# Altium schematic sheet HMIv2.SchDoc
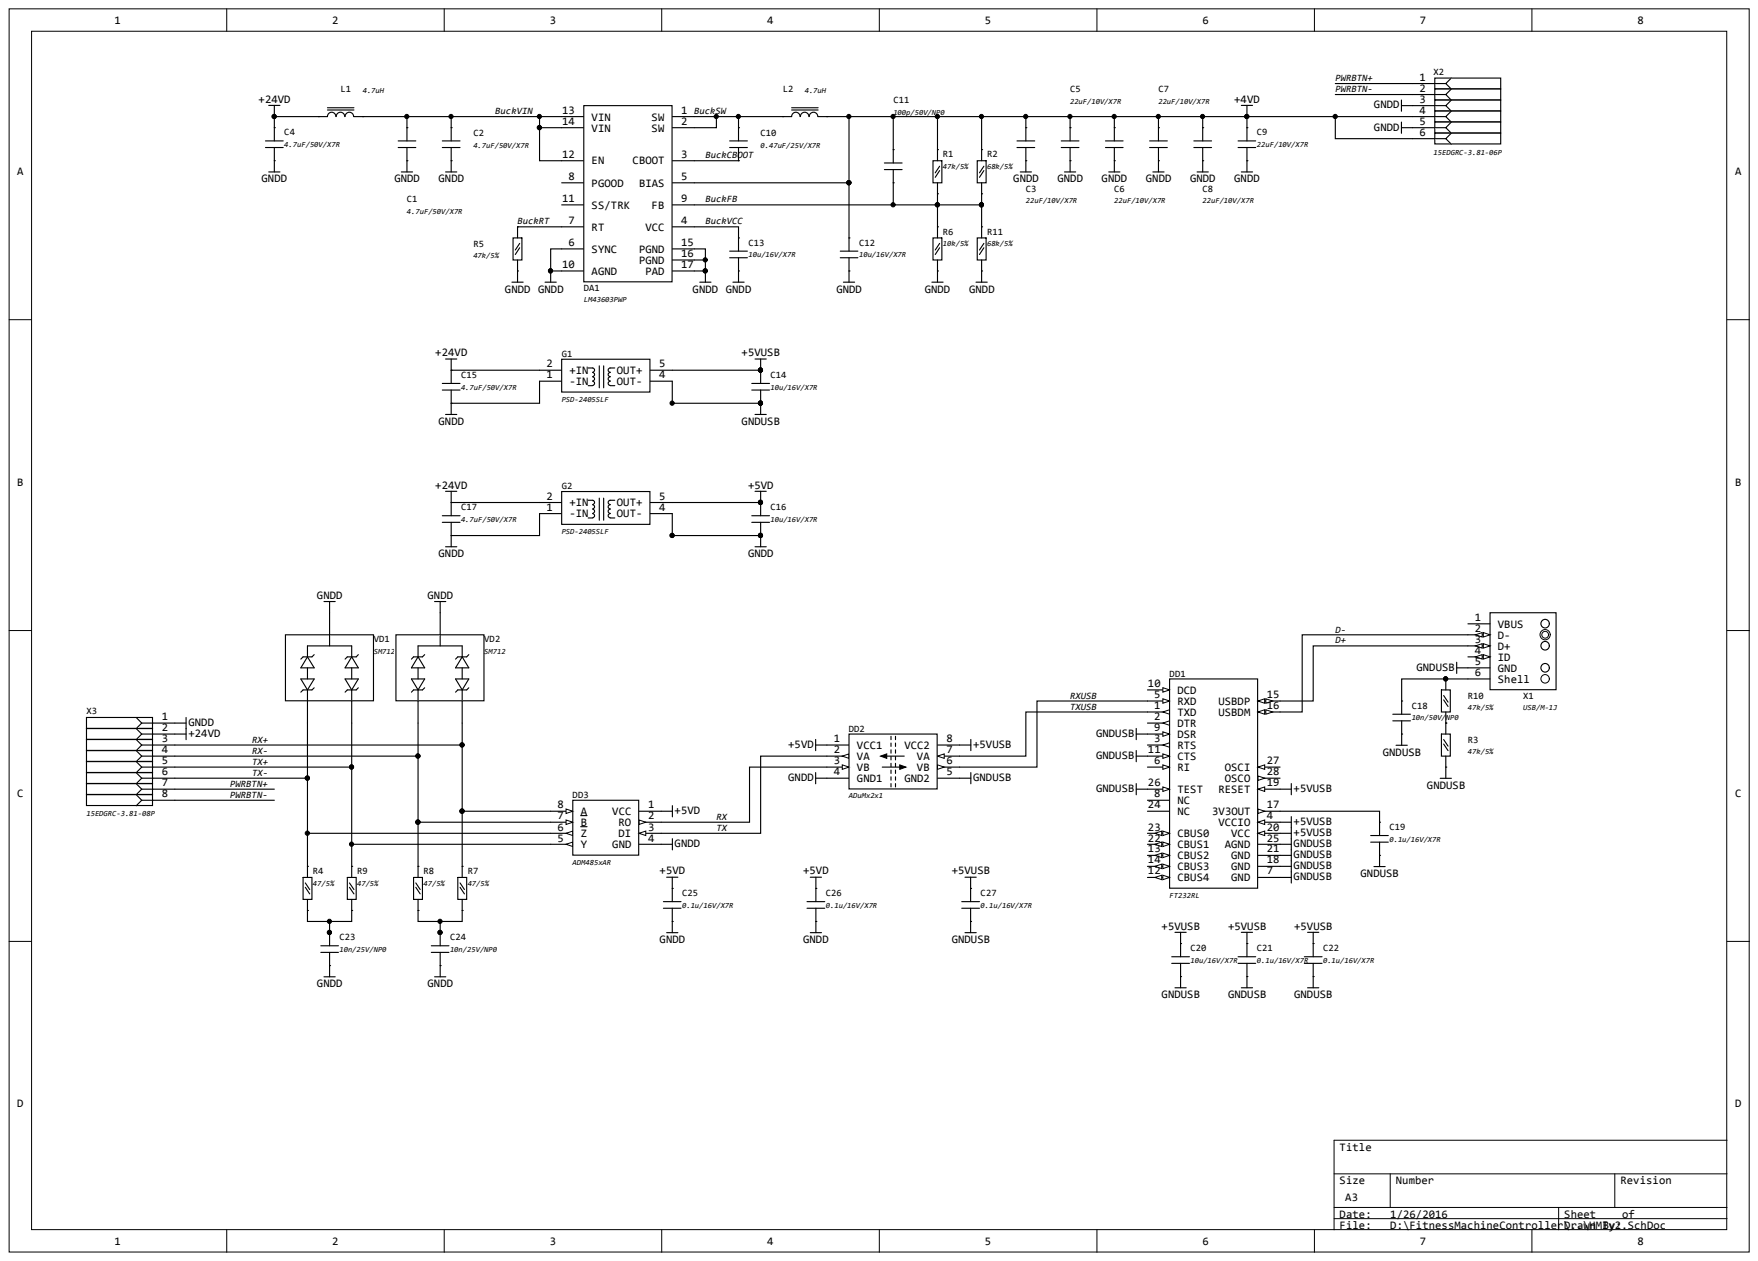
[diagram p0: HMIv2.SchDoc]

## Parts
C1  4.7uF/50V/X7R
C2  4.7uF/50V/X7R
C3  22uF/10V/X7R
C4  4.7uF/50V/X7R
C5  22uF/10V/X7R
C6  22uF/10V/X7R
C7  22uF/10V/X7R
C8  22uF/10V/X7R
C9  22uF/10V/X7R
C10  0.47uF/25V/X7R
C11  100p/50V/NP0
C12  10u/16V/X7R
C13  10u/16V/X7R
C14  10u/16V/X7R
C15  4.7uF/50V/X7R
C16  10u/16V/X7R
C17  4.7uF/50V/X7R
C18  10n/50V/NP0
C19  0.1u/16V/X7R
C20  10u/16V/X7R
C21  0.1u/16V/X7R
C22  0.1u/16V/X7R
C23  10n/25V/NP0
C24  10n/25V/NP0
C25  0.1u/16V/X7R
C26  0.1u/16V/X7R
C27  0.1u/16V/X7R
DA1  LM43603PWP
DD1  FT232RL
DD2  ADuMx2x1
DD3  ADM485xAR
G1  PSD-2405SLF
G2  PSD-2405SLF
L1  4.7uH
L2  4.7uH
R1  47k/5%
R2  68k/5%
R3  47k/5%
R4  47/5%
R5  47k/5%
R6  10k/5%
R7  47/5%
R8  47/5%
R9  47/5%
R10  47k/5%
R11  68k/5%
VD1  SM712
VD2  SM712
X1  USB/M-1J
X2  15EDGRC-3.81-06P
X3  15EDGRC-3.81-08P

## Nets
+4VD: C3.2 C5.2 C6.2 C7.2 C8.2 C9.2 C11.2 C12.2 DA1.5(BIAS) L2.2 R1.2 R2.2 X2.4 X2.6
+5VD: C16.2 C25.2 C26.2 DD2.1(VCC1) DD3.1(VCC) G2.5(OUT+)
+5VUSB: C14.2 C20.2 C21.2 C22.2 C27.2 DD1.4(VCCIO) DD1.19(RESET) DD1.20(VCC) DD2.8(VCC2) G1.5(OUT+)
+24VD: C4.2 C15.2 C17.2 G1.2(+IN) G2.2(+IN) L1.1 X3.2
GNDD: C1.1 C2.1 C3.1 C4.1 C5.1 C6.1 C7.1 C8.1 C9.1 C12.1 C13.1 C15.1 C16.1 C17.1 C23.1 C24.1 C25.1 C26.1 DA1.6(SYNC) DA1.10(AGND) DA1.15(PGND) DA1.16(PGND) DA1.17(PAD) DD2.4(GND1) DD3.4(GND) G1.1(-IN) G2.1(-IN) G2.4(OUT-) R5.1 R6.1 R11.1 VD1.3 VD2.3 X2.3 X2.5 X3.1
GNDUSB: C14.1 C18.2 C19.1 C20.1 C21.1 C22.1 C27.1 DD1.7(GND) DD1.9(DSR) DD1.11(CTS) DD1.18(GND) DD1.21(GND) DD1.25(AGND) DD1.26(TEST) DD2.5(GND2) G1.4(OUT-) R3.1 X1.5(GND)
BUCKCBOOT: C10.1 DA1.3(CBOOT)
BUCKFB: C11.1 DA1.9(FB) R1.1 R2.1 R6.2 R11.2
BUCKRT: DA1.7(RT) R5.2
BUCKSW: C10.2 DA1.1(SW) DA1.2(SW) L2.1
BUCKVCC: C13.2 DA1.4(VCC)
BUCKVIN: C1.2 C2.2 DA1.12(EN) DA1.13(VIN) DA1.14(VIN) L1.2
D+: DD1.15(USBDP) X1.3(D+)
D-: DD1.16(USBDM) X1.2(D-)
PWRBTN+: X2.1 X3.7
PWRBTN-: X2.2 X3.8
RX: DD2.3(VB) DD3.2(RO)
RX+: DD3.8(A) R7.2 VD2.1 X3.3
RX-: DD3.7(B) R8.2 VD2.2 X3.4
RXUSB: DD1.5(RXD) DD2.6(VB)
TX: DD2.2(VA) DD3.3(DI)
TX+: DD3.5(Y) R9.2 VD1.1 X3.5
TX-: DD3.6(Z) R4.2 VD1.2 X3.6
TXUSB: DD1.1(TXD) DD2.7(VA)
NetC18_1: C18.1 R10.2 X1.6(Shell)
NetC19_2: C19.2 DD1.17(3V3OUT)
NetC23_2: C23.2 R4.1 R9.1
NetC24_2: C24.2 R7.1 R8.1
NetR3_2: R3.2 R10.1

Not connected: DA1 8(PGOOD) 11(SS/TRK); DD1 2(DTR) 3(RTS) 6(RI) 8(NC) 10(DCD) 12(CBUS4) 13(CBUS2) 14(CBUS3) 22(CBUS1) 23(CBUS0) 24(NC) 27(OSCI) 28(OSCO); X1 1(VBUS) 4(ID)

## Library data of the parts
C1-C9 C15 C17 = (no symbol name): ComponentDescriptionRUS: Конденсатор SMD 1210; Analog: нет; NumberSolderPointsSMD: 0; NumberSolderPointsTHT: 0
C10 C18 = (no symbol name): ComponentDescriptionRUS: Конденсатор SMD 0805; Analog: нет; NumberSolderPointsSMD: 0; NumberSolderPointsTHT: 0
C11 C23 C24 = (no symbol name): Описание: Конденсатор SMD 0603; NumberSolderPointsSMD: 0; Аналог: нет; NumberSolderPointsTHT: 0
C12-C14 C16 C20 = (no symbol name): ComponentDescriptionRUS: Конденсатор SMD 1206; Analog: нет; NumberSolderPointsSMD: 0; NumberSolderPointsTHT: 0
C19 C21 C22 C25-C27 = (no symbol name): ComponentDescriptionRUS: Конденсатор SMD 0603; Analog: нет; NumberSolderPointsSMD: 0; NumberSolderPointsTHT: 0
DA1 = (no symbol name): ComponentDescriptionRUS: Микросхема TSSOP16
DD1 = (no symbol name): ComponentDescriptionRUS: Микросхема SSOP28; Analog: нет; NumberSolderPointsSMD: 0; NumberSolderPointsTHT: 0
DD2 = (no symbol name): ComponentDescriptionRUS: Микросхема SO8; Analog: нет; NumberSolderPointsSMD: 0; NumberSolderPointsTHT: 0
DD3 = (no symbol name): ComponentDescriptionRUS: Микросхема SO8; Аналог: нет; NumberSolderPointsSMD: 0; NumberSolderPointsTHT: 0
G1 G2 = (no symbol name): ComponentDescriptionRUS: Модуль питания; Analog: нет; NumberSolderPointsSMD: 0; NumberSolderPointsTHT: 0
L1 L2 = (no symbol name): ComponentDescriptionRUS: Индуктивность; Analog: нет; NumberSolderPointsSMD: 0; NumberSolderPointsTHT: 0
R1-R4 R6-R11 = (no symbol name): ComponentDescriptionRUS: Резистор SMD 0603; Analog: нет; NumberSolderPointsSMD: 0; NumberSolderPointsTHT: 0
R5 = (no symbol name): Описание: Резистор SMD 0805; NumberSolderPointsSMD: 0; Аналог: нет; NumberSolderPointsTHT: 0
VD1 VD2 = (no symbol name): Описание: Диод SMB; NumberSolderPointsSMD: 0; Аналог: нет; NumberSolderPointsTHT: 0
X1 = (no symbol name): ComponentDescriptionRUS: Разъем USB mini; Analog: нет; NumberSolderPointsSMD: 0; NumberSolderPointsTHT: 0
X2 = (no symbol name): Аналог: ECH381R-06P; Описание: Клеммник разъемный 3.81мм; NumberSolderPointsSMD: 0; Комплект: EC381V-06P, 15EDGK-3.81-06; NumberSolderPointsTHT: 0
X3 = (no symbol name): Аналог: ECH381R-08P; ComponentDescriptionRUS: Клеммник разъемный 3.81мм; NumberSolderPointsSMD: 0; Комплект: EC381V-08P, 15EDGK-3.81-08; NumberSolderPointsTHT: 0
